# Altium schematic sheet top_media_conglomerate_adult_v01.2.SchDoc
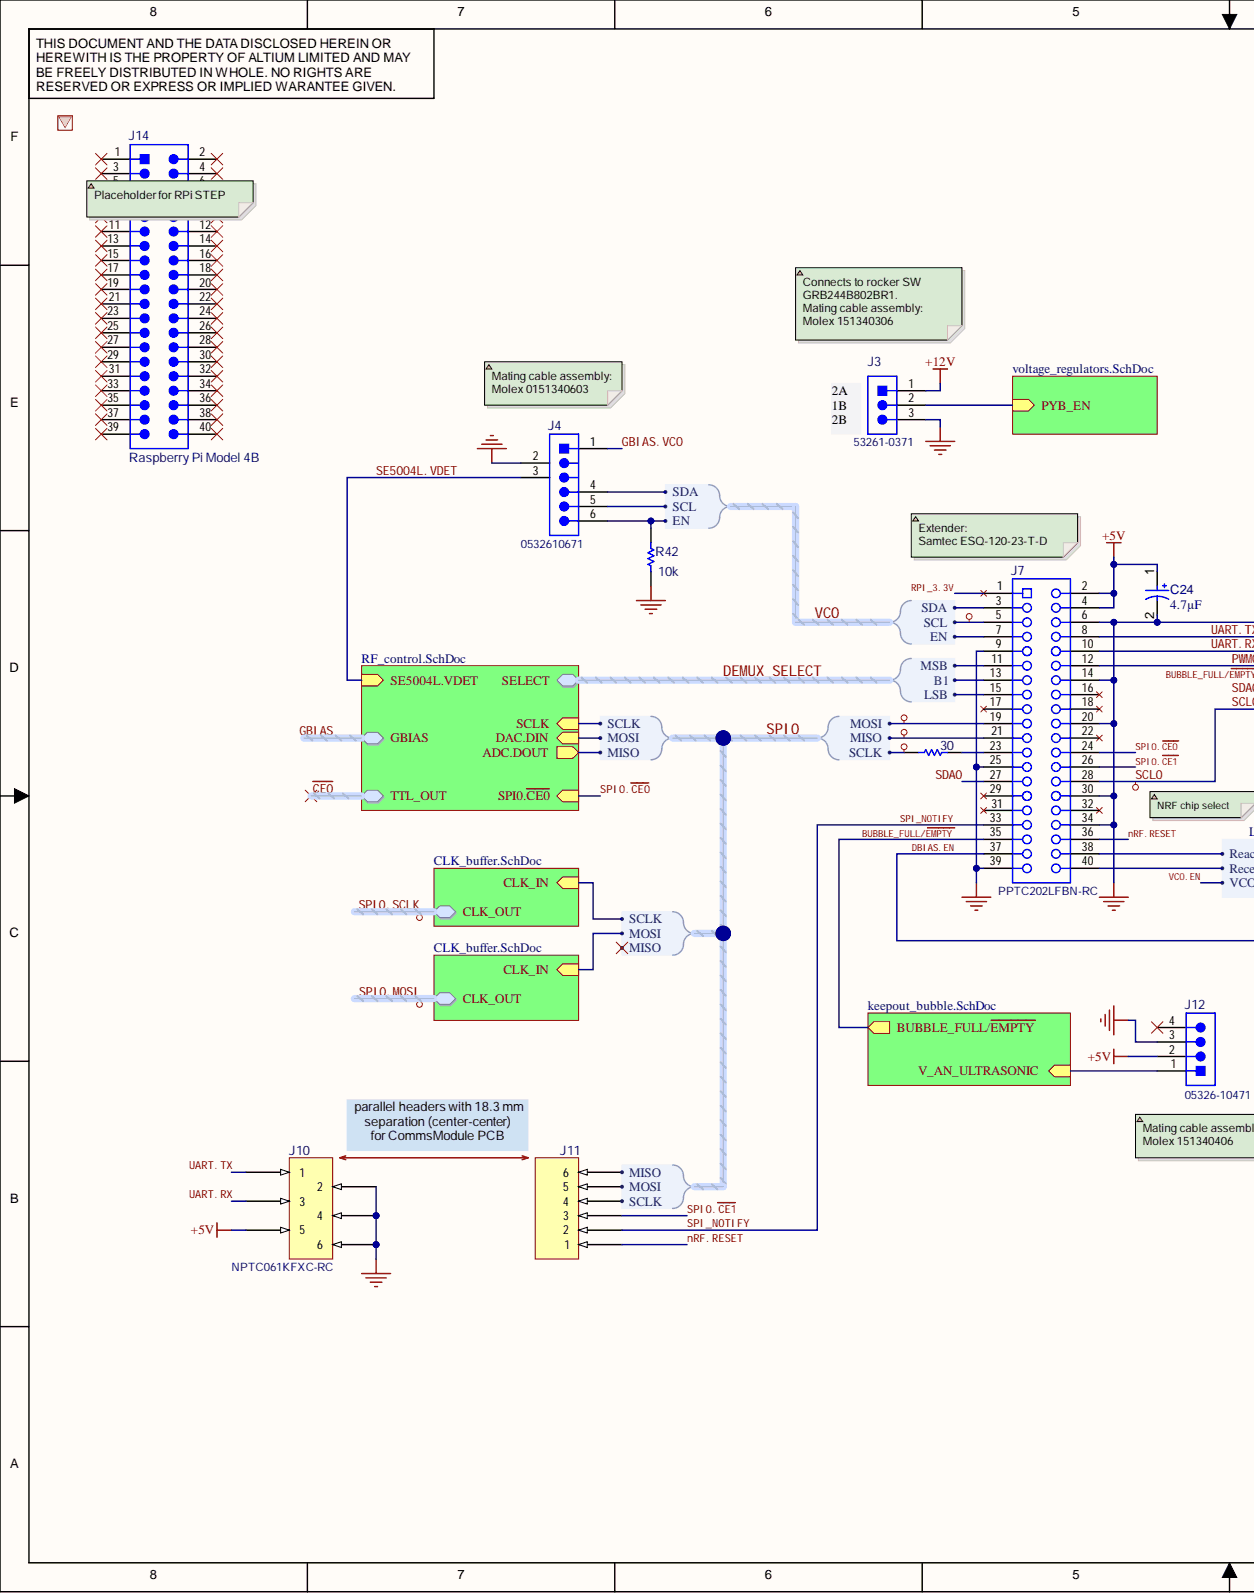
[diagram p0: top_media_conglomerate_adult_v01.2.SchDoc, left]
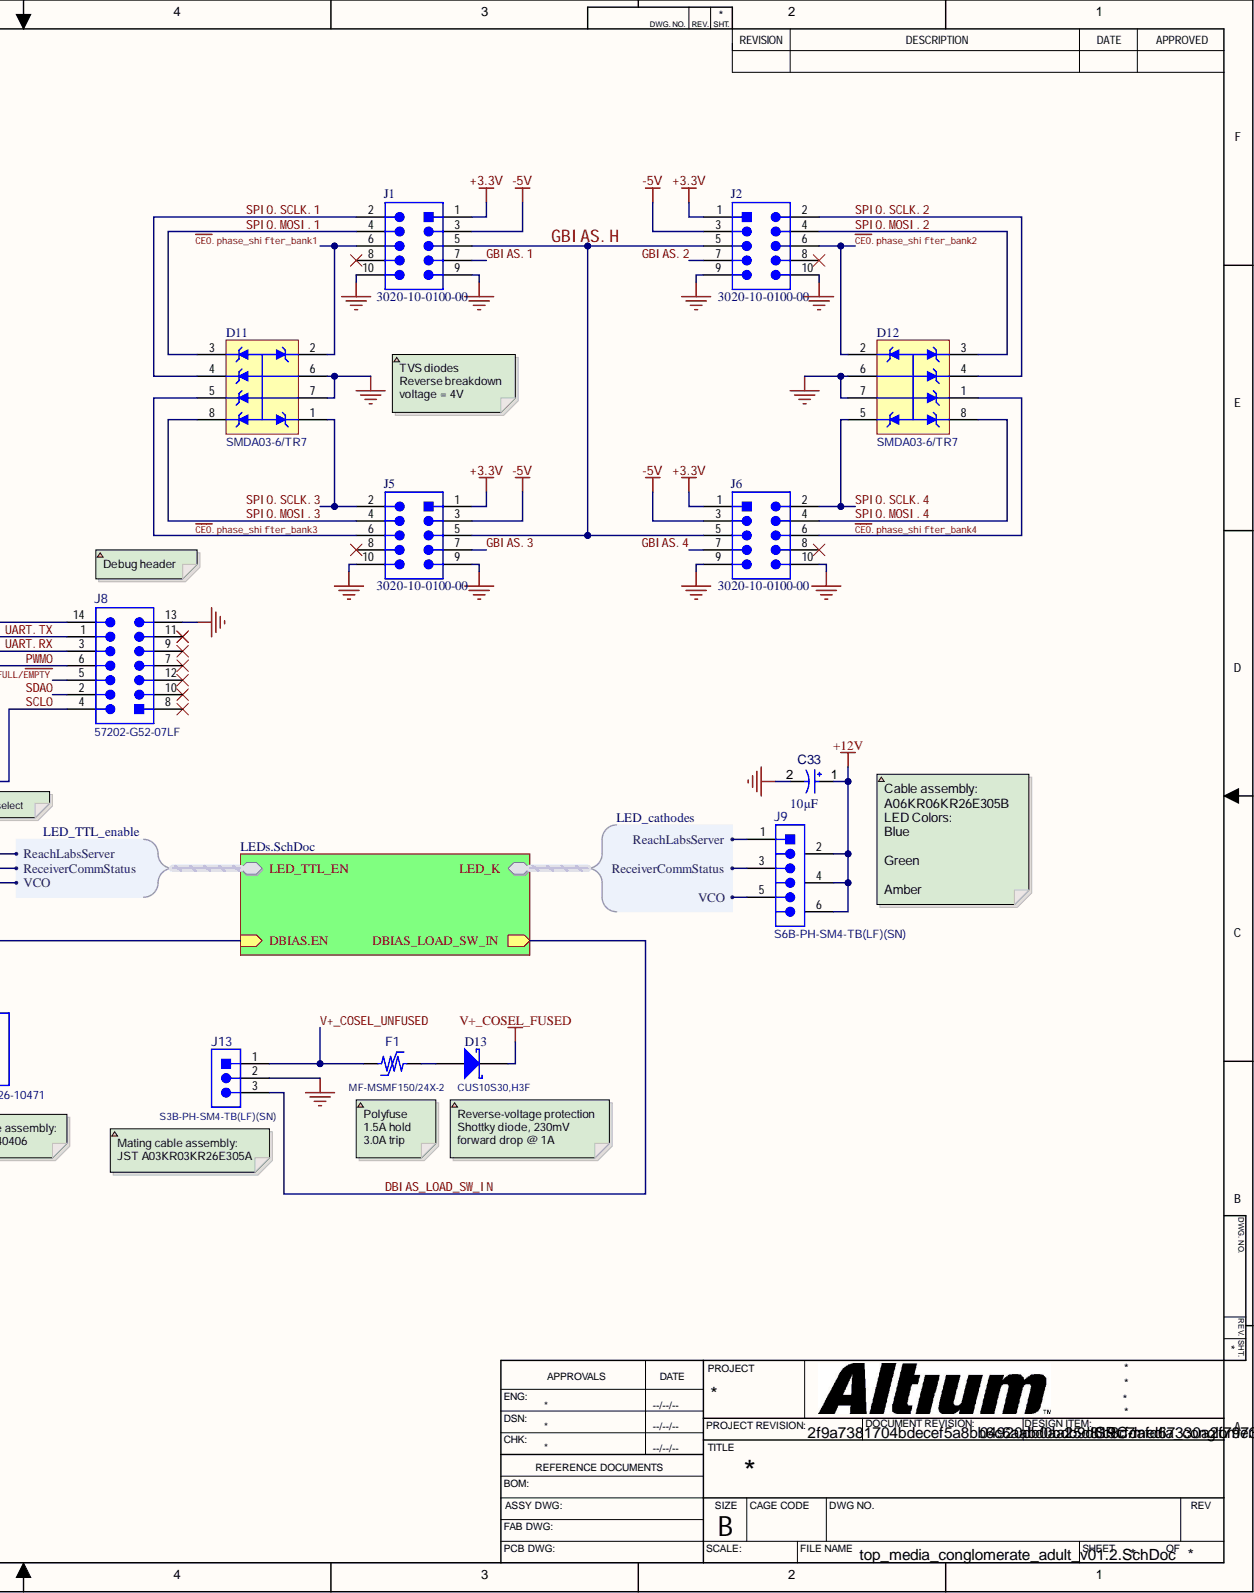
[diagram p1: top_media_conglomerate_adult_v01.2.SchDoc, right]

## Parts
C24  value 4.7µF  [AVX-TAJ-A-2_N]  (hidden comment: TAJA475K016RNJ)
C33  value 10µF  [CAPMP3529X21-T_V]  (hidden comment: TAJB106M016RNJ)
D11  SMDA03-6/TR7  [SOIC-8_500X400MM_127PITCH_N]
D12  SMDA03-6/TR7  [SOIC-8_500X400MM_127PITCH_N]
D13  CUS10S30,H3F  [DIOM1813X11N]
F1  MF-MSMF150/24X-2  [BOUR-MF-MSMF110-24X-2_V]
J1  3020-10-0100-00  [3020-10-0100-00-Footprint-1]
J2  3020-10-0100-00  [3020-10-0100-00-Footprint-1]
J3  53261-0371  [0532610371]
J4  0532610671  [05326-10671]
J5  3020-10-0100-00  [3020-10-0100-00-Footprint-1]
J6  3020-10-0100-00  [3020-10-0100-00-Footprint-1]
J7  PPTC202LFBN-RC  [PPTC202LFBN-RC]
J8  57202-G52-07LF  [57202-G52-07LF-Footprint-1]
J9  S6B-PH-SM4-TB(LF)(SN)  [JST-S6B-PH-SM4-TB(LF)(SN)_V]
J10  NPTC061KFXC-RC  [NPTC061KFXC-RC_with_comms_module]
J11  NPTC061KFXC-RC  [NPTC061KFXC-RC-Footprint-1]
J12  05326-10471  [CON-RT_4PIN_MOLEX_05326-10471]
J13  S3B-PH-SM4-TB(LF)(SN)  [JST-S3B-PH-SM4-TB-3_V]
J14  Raspberry Pi Model 4B  [Raspberry Pi Model 4B-Footprint-1]
R42  value 10k  [RESTF_0402(1005M)_SMD_N]  (hidden comment: RC0402JR-0710KL)
R43  value 30  [RESTF_0402(1005M)_SMD_L]  (hidden comment: RC0402JR-0730RL)

## Nets
+3.3V: J1.1 J2.1 J5.1 J6.1
+5V: C24.1 J7.2 J7.4 J10.5 J12.2
+12V: C33.1 J3.1 J9.2 J9.4 J9.6
-5V: J1.3 J2.3 J5.3 J6.3
GND: C24.2 C33.2 D11.6 D11.7 D12.6 D12.7 J1.9 J1.10 J2.9 J2.10 J3.3 J4.2 J5.9 J5.10 J6.9 J6.10 J7.6 J7.9 J7.14 J7.20 J7.25 J7.30 J7.34 J7.39 J8.13 J8.14 J10.2 J10.4 J10.6 J12.3 J13.2 R42.2
V+_COSEL_FUSED: D13.1
~{DBIAS_LOAD_SW_IN}: J13.3
BUBBLE_FULL/~{EMPTY}: J7.35 J8.5
~{CE0}.phase_shifter_bank1: D11.2 J1.6
~{CE0}.phase_shifter_bank2: D12.2 J2.6
~{CE0}.phase_shifter_bank3: D11.5 J5.6
~{CE0}.phase_shifter_bank4: D12.1 J6.6
DBIAS.EN: J7.37
DEMUX_SELECT.B1: J7.13
DEMUX_SELECT.LSB: J7.15
DEMUX_SELECT.MSB: J7.11
GBIAS.1: J1.7
GBIAS.2: J2.7
GBIAS.3: J5.7
GBIAS.4: J6.7
GBIAS.H: J1.5 J2.5 J5.5 J6.5
GBIAS.VCO: J4.1
nRF.RESET: J7.36 J11.1
PWM0: J7.12 J8.6
RPI_3.3V: J7.1
SCL0: J7.28 J8.4
SDA0: J7.27 J8.2
SE5004L.VDET: J4.3
SPI0.~{CE0}: J7.24
SPI0.~{CE1}: J7.26 J11.3
SPI0.MISO: J7.21 J11.6
SPI0.MOSI: J7.19 J11.5
SPI0.MOSI.1: D11.3 J1.4
SPI0.MOSI.2: D12.3 J2.4
SPI0.MOSI.3: D11.8 J5.4
SPI0.MOSI.4: D12.8 J6.4
SPI0.SCLK: J11.4 R43.1
SPI0.SCLK.1: D11.4 J1.2
SPI0.SCLK.2: D12.4 J2.2
SPI0.SCLK.3: D11.1 J5.2
SPI0.SCLK.4: D12.5 J6.2
SPI_NOTIFY: J7.33 J11.2
UART.RX: J7.10 J8.3 J10.3
UART.TX: J7.8 J8.1 J10.1
V+_COSEL_UNFUSED: F1.1 J13.1
VCO.EN: J4.6 J7.7 R42.1
VCO.SCL: J4.5 J7.5
VCO.SDA: J4.4 J7.3
NetD13_2: D13.2 F1.2
NetJ1_8: J1.8
NetJ2_8: J2.8
NetJ3_2: J3.2
NetJ5_8: J5.8
NetJ6_8: J6.8
NetJ7_16: J7.16
NetJ7_17: J7.17
NetJ7_18: J7.18
NetJ7_22: J7.22
NetJ7_23: J7.23 R43.2
NetJ7_29: J7.29
NetJ7_31: J7.31
NetJ7_32: J7.32
NetJ7_38: J7.38
NetJ7_40: J7.40
NetJ8_7: J8.7
NetJ8_8: J8.8
NetJ8_9: J8.9
NetJ8_10: J8.10
NetJ8_11: J8.11
NetJ8_12: J8.12
NetJ9_1: J9.1
NetJ9_3: J9.3
NetJ9_5: J9.5
NetJ12_1: J12.1
NetJ12_4: J12.4
NetJ14_1: J14.1
NetJ14_2: J14.2
NetJ14_3: J14.3
NetJ14_4: J14.4
NetJ14_5: J14.5
NetJ14_6: J14.6
NetJ14_7: J14.7
NetJ14_8: J14.8
NetJ14_9: J14.9
NetJ14_10: J14.10
NetJ14_11: J14.11
NetJ14_12: J14.12
NetJ14_13: J14.13
NetJ14_14: J14.14
NetJ14_15: J14.15
NetJ14_16: J14.16
NetJ14_17: J14.17
NetJ14_18: J14.18
NetJ14_19: J14.19
NetJ14_20: J14.20
NetJ14_21: J14.21
NetJ14_22: J14.22
NetJ14_23: J14.23
NetJ14_24: J14.24
NetJ14_25: J14.25
NetJ14_26: J14.26
NetJ14_27: J14.27
NetJ14_28: J14.28
NetJ14_29: J14.29
NetJ14_30: J14.30
NetJ14_31: J14.31
NetJ14_32: J14.32
NetJ14_33: J14.33
NetJ14_34: J14.34
NetJ14_35: J14.35
NetJ14_36: J14.36
NetJ14_37: J14.37
NetJ14_38: J14.38
NetJ14_39: J14.39
NetJ14_40: J14.40
~{CE0}.6:
~{CE0}.7:
~{CE0}.ADC:
~{CE0}.DAC:

## Library data of the parts
C24 = CMP-001-00042-10: Standard Tantalum, 4.7 uF, +/- 10%, 16 V, -55 to 125 degC, 1206 (3216 Metric), RoHS, Tape and Reel; Manufacturer: AVX; ESR: 4Ohm; Voltage Rating: 16V; Capacitor Type: Tantalum; Case/Package: 1206; Component Kind: Standard; Datasheet URL: http://datasheets.avx.com/TAJ.pdf; Datasheet Version: Feb-16; Library Name: Altium Concord Pro on A365; Manufacturer URL: http://www.avx.com/; Max Operating Temperature: 125°C; Min Operating Temperature: -55°C; Mounting Technology: SM; Package Description: 2-Pin Surface Mount Device, Body 3.2 x 1.65 mm; Package Quantity: 2000; Package Reference: TAJ-A-2; Packaging: TapeandReel; Pins: 2; Resistance: 4Ohm; RoHS Compliant: True; Tolerance: 10%
C33 = CMP-001-00050-8: Tantalum Capacitor, 10 uF, +/- 20%, 16 V, -55 to 125 degC, 1411 (3528 Metric), RoHS, Tape and Reel; Manufacturer: AVX Corporation; Voltage Rating: 16V; Capacitor Type: Tantalum; Case/Package: 1210; Component Kind: Standard; Datasheet URL: http://datasheets.avx.com/TAJ.pdf; Datasheet Version: 42736; Library Name: Altium Concord Pro on A365; Manufacturer URL: http://www.avx.com/; Max Operating Temperature: 125°C; Min Operating Temperature: -55°C; Mounting Technology: SM; MPN: TAJB106M016RNJ; Package Description: 2-Pin Surface Mount Device, Body 3.5 x 2.85 mm; Package Quantity: 1; Package Reference: 3528-21; Packaging: TapeandReel; Pins: 2; RoHS Compliant: True; Tolerance: 20%
D11 D12 = CMP-005-0002-3: TVS DIODE 3.3V 7V 8SO; Direction: Unidirectional; Clamping Voltage: 7V; Component Kind: Standard; Library Name: Altium Concord Pro on A365; Max Operating Temperature: 150°C; Min Operating Temperature: -55°C; Mount: Surface Mount; MPN: SMDA03-6/TR7; Number of Pins: 8; Radiation Hardening: No; Working Voltage: 3.3V
D13 = CMP-004-00032-5: DIODE SCHOTTKY 30V 1A USC; Element Configuration: Single; Peak Non-Repetitive Surge Current: 5A; Peak Reverse Current: 500uA; Average Rectified Current: 1A; Case/Package: SOD; Component Kind: Standard; Contact Plating: Tin,Silver; Library Name: Altium Concord Pro on A365; Max Operating Temperature: 125°C; Max Reverse Voltage (DC): 30V; Min Operating Temperature: -55°C; Mount: Surface Mount; Number of Pins: 2; Packaging: Cut Tape
F1 = CMP-005-00013-1: PTC Resettable Fuse 1.5A(hold) 3A(trip) 24V 20A 1W 1.5s 0.03Ohm SMD Solder Pad 1812 T/R; Approvals: UL,CSA,TUV; Case Code (Imperial): 1812; Case Code (Metric): 4532; Case/Package: 1812; Component Kind: Standard; Contact Plating: Gold; Current Rating: 1.5A; Height: 1.6mm; Hold Current: 1.5A; Length: 4.83mm; Library Name: Altium Concord Pro on A365; Max Current Rating: 20A; Max Operating Temperature: 85°C; Min Operating Temperature: -40°C; Mount: Surface Mount; Mounting Technology: SM; Number of Terminals: 2; Packaging: Tape and Reel; Power Dissipation: 1W; Radiation Hardening: No; REACH SVHC: No SVHC; RoHS Compliant: True; Terminal Material: Gold; Time to Trip: 1.5s; Trip Current: 3A; Voltage Rating: 24V; Voltage Rating (DC): 24V; Width: 3.41mm
J1 J2 J5 J6 = CMP-002-00103-3: CONN HEADER VERT 10POS 2.54MM; Connector Type: Male Pin; Number of Positions: 10; Pitch: 0.1inch; Component Kind: Standard; Contact Plating: Gold; Library Name: Altium Concord Pro on A365; Mount: Through Hole; MPN: 3020-10-0100-00; Number of Rows: 2; Package Quantity: 1560; Packaging: Tray; Row Spacing: 0.1inch; Termination: Solder
J3 = CMP-002-00085-2: CONN HEADER SMD R/A 3POS 1.25MM; Connector Type: Blade Pin; Contact Resistance: 20mR; Gender: Male; Number of Contacts: 3; System: Connector; Color: Natural; Component Kind: Standard; Contact Material: Bronze; Contact Plating: Tin; Depth: 5.2mm; Flammability Rating: UL94 V-0; Housing Color: Natural; Housing Material: Nylon; Length: 8.9mm; Library Name: Altium Concord Pro on A365; Max Operating Temperature: 85°C; Min Operating Temperature: -40°C; Mount: Surface Mount; Number of Positions: 3; Number of Rows: 1; Orientation: Right Angle; Packaging: Tape and Reel; Pins: 3; Pitch: 1.25mm; Termination: Solder
J4 = CMP-002-00086-1: Conn Shrouded Header HDR 6 POS 1.25mm Solder RA SMD PicoBlade™ Embossed T/R; Connector Type: Blade Pin; Contact Resistance: 20mR; Gender: Male; Number of Contacts: 6; System: Connector; Case/Package: 1000; Color: Natural; Component Kind: Standard; Contact Material: Bronze; Contact Plating: Tin; Depth: 5.2mm; Flammability Rating: UL94 V-0; Housing Color: Natural; Housing Material: Nylon; Length: 12.65mm; Library Name: Altium Concord Pro on A365; Max Operating Temperature: 85°C; Min Operating Temperature: -40°C; Mount: Surface Mount; Number of Positions: 6; Number of Rows: 1; Orientation: Right Angle; Packaging: Tape and Reel; Pitch: 1.25mm; Termination: Solder
J7 = CMP-009-00203-5: CONN HDR 40POS 0.1 TIN PCB; Connector Type: Header,Socket; Number of Positions: 40; Pitch: 0.1inch; System: Connector; Color: Black; Component Kind: Standard; Contact Material: Bronze; Contact Plating: Tin; Height: 8.5mm; Housing Material: Plastic; Lead Free: Lead Free; Length: 51.3mm; Library Name: Altium Concord Pro on A365; Mount: Through Hole; Number of Rows: 2; Package Quantity: 1000; Packaging: Bulk; Row Spacing: 0.1inch; Termination: Solder; Width: 5mm
J8 = CMP-002-00104-1: CONN HEADER 14POS 2MM STR DL SMD; Connector Type: Male Pin; Contact Resistance: 25mR; Current Rating: 2A; Gender: Male; Insulation Resistance: 1GR; Max Voltage Rating (AC): 650V; Number of Contacts: 14; Row Spacing: 0.079inch; System: Test; Color: Black; Component Kind: Standard; Contact Material: Bronze,Copper Alloy; Contact Mating Length: 0.157inch; Contact Plating: Gold,GXT; Depth: 4mm; Housing Color: Black; Housing Material: Thermoplastic; Lead Free: Lead Free; Length: 14mm; Library Name: Altium Concord Pro on A365; Max Operating Temperature: 125°C; Min Operating Temperature: -55°C; Mount: Surface Mount; MPN: 57202-G52-07LF; Number of Positions: 14; Number of Rows: 2; Orientation: Straight; Package Quantity: 200; Packaging: Bulk; Pitch: 2mm; Radiation Hardening: No; REACH SVHC: No SVHC; Termination: Solder; Voltage Rating: 650V; Width: 4mm
J9 = CMP-002-00099-1: CONN HEADER SMD R/A 6POS 2MM; Connector Type: Header,Male Pin; Contact Resistance: 20mR; Current Rating: 2A; Gender: Male; Insulation Resistance: 1GR; Max Voltage Rating (AC): 100V; Number of Contacts: 6; System: Connector; Color: White; Component Kind: Standard; Contact Material: Copper Alloy,Bronze,Tin,Brass; Contact Mating Length: 0.13inch; Contact Plating: Tin,Copper; Contact Shape: Square; Depth: 7.6mm; Fastening Type: Detent; Flammability Rating: UL94 V-0; Height: 9.4mm; Housing Color: Beige; Housing Material: Polyamide; Insulation Color: Natural; Insulation Material: Nylon; Lead Free: Lead Free; Lead Pitch: 2mm; Length: 15.9mm; Library Name: Altium Concord Pro on A365; Max Current Rating: 2A; Max Operating Temperature: 85°C; Max Voltage Rating (DC): 100V; Min Operating Temperature: -25°C; Mount: Surface Mount; MPN: S6B-PH-SM4-TB(LF)(SN); Number of Poles: 6; Number of Positions: 6; Number of Rows: 1; Orientation: Right Angle; Packaging: Tape and Reel; Pitch: 2mm; REACH SVHC: No SVHC; Termination: Solder; Voltage Rating: 100V; Voltage Rating (AC): 100V; Width: 5mm; Working Voltage: 100V
J10 J11 = CMP-002-00087-2: CONN HDR 6POS 0.1 TIN SMD; Connector Type: Header,Socket; Number of Positions: 6; Pitch: 0.1inch; System: Connector; Color: Black; Component Kind: Standard; Contact Material: Bronze; Contact Plating: Tin; Height: 7.1mm; Housing Color: Black; Housing Material: Nylon; Lead Free: Lead Free; Length: 15.2mm; Library Name: Altium Concord Pro on A365; Mount: Surface Mount; Number of Rows: 1; Package Quantity: 1000; Packaging: Bulk; Termination: Solder; Width: 2.5mm
J12 = CMP-002-00071-2: 1.25mm (.049") Pitch PicoBlade Header, Surface Mount, Right Angle, Lead-free, 4 Circuits; Manufacturer: Molex; Manufacturer Part Number: 53261-0471; Contact Resistance: 20mR; Current Rating: 1A; Gender: Male; Glow Wire Compliant: Non-compliant; Insulation Resistance: 100MR; Number of Circuits: 4; System: Connector; Voltage Rating: 125V; Case/Package: 1000; Color: Beige; Component Kind: Standard; ComponentLink1Description: https://datasheet.ciiva.com/5153/0532610471-pcb-headers-170942-5153858.pdf?src-supplier=Mouser; ComponentLink1URL: https://datasheet.ciiva.com/5153/0532610471-pcb-headers-170942-5153858.pdf?src-supplier=Mouser; ComponentLink2Description: https://datasheet.ciiva.com/3932/272700532610471-pcb-headers-3932851.pdf?src-supplier=Arrow; ComponentLink2URL: https://datasheet.ciiva.com/3932/272700532610471-pcb-headers-3932851.pdf?src-supplier=Arrow; ComponentLink3Description: https://datasheet.ciiva.com/11750/0900766b8078fc7e-11750771.pdf?src-supplier=RSComponents; ComponentLink3URL: https://datasheet.ciiva.com/11750/0900766b8078fc7e-11750771.pdf?src-supplier=RSComponents; ComponentLink4Description: https://datasheet.ciiva.com/30219/60210532610471-pcb-headers-30219733.pdf?src-supplier=Onlinecomponents.com; ComponentLink4URL: https://datasheet.ciiva.com/30219/60210532610471-pcb-headers-30219733.pdf?src-supplier=Onlinecomponents.com; ComponentLink5Description: https://datasheet.ciiva.com/7844/1867384-7844038.pdf?src-supplier=Element14; ComponentLink5URL: https://datasheet.ciiva.com/7844/1867384-7844038.pdf?src-supplier=Element14; ComponentLink6Description: https://datasheet.ciiva.com/18448/70091119-18448940.pdf?src-supplier=Allied+Electronics; ComponentLink6URL: https://datasheet.ciiva.com/18448/70091119-18448940.pdf?src-supplier=Allied+Electronics; ComponentLink7Description: http://datasheet.octopart.com/532610471-Molex-datasheet-8343080.pdf?src-supplier=TME; ComponentLink7URL: http://datasheet.octopart.com/532610471-Molex-datasheet-8343080.pdf?src-supplier=TME; ComponentLink8Description: http://datasheet.octopart.com/53261-0471-Molex-datasheet-8522546.pdf?src-supplier=Factory+Futures; ComponentLink8URL: http://datasheet.octopart.com/53261-0471-Molex-datasheet-8522546.pdf?src-supplier=Factory+Futures; ComponentLink9Description: http://datasheet.octopart.com/53261-0471-Molex-datasheet-12521482.pdf?src-supplier=Factory+Futures; ComponentLink9URL: http://datasheet.octopart.com/53261-0471-Molex-datasheet-12521482.pdf?src-supplier=Factory+Futures; ComponentLink10Description: http://datasheet.octopart.com/53261-0471-Molex-datasheet-13786152.pdf?src-supplier=Sager+Electronics; ComponentLink10URL: http://datasheet.octopart.com/53261-0471-Molex-datasheet-13786152.pdf?src-supplier=Sager+Electronics; ComponentLink11Description: http://sigma.octopart.com/16413766/technical_drawing/Molex-53261-0471.pdf?src-supplier=Molex; ComponentLink11URL: http://sigma.octopart.com/16413766/technical_drawing/Molex-53261-0471.pdf?src-supplier=Molex; ComponentLink12Description: http://datasheet.octopart.com/53261-0471-Molex-datasheet-14711293.pdf?src-supplier=Farnell; ComponentLink12URL: http://datasheet.octopart.com/53261-0471-Molex-datasheet-14711293.pdf?src-supplier=Farnell; ComponentLink13Description: http://datasheet.octopart.com/53261-0471-Molex-datasheet-66578537.pdf?src-supplier=Element14; ComponentLink13URL: http://datasheet.octopart.com/53261-0471-Molex-datasheet-66578537.pdf?src-supplier=Element14; ComponentLink14Description: http://datasheet.octopart.com/53261-0471-Molex-datasheet-101583401.pdf?src-supplier=Farnell; ComponentLink14URL: http://datasheet.octopart.com/53261-0471-Molex-datasheet-101583401.pdf?src-supplier=Farnell; ComponentLink15Description: http://datasheet.octopart.com/53261-0471-Molex-datasheet-143843.pdf?src-supplier=Digi-Key; ComponentLink15URL: http://datasheet.octopart.com/53261-0471-Molex-datasheet-143843.pdf?src-supplier=Digi-Key; ComponentLink16Description: http://datasheet.octopart.com/532610471-Molex-datasheet-520094.pdf?src-supplier=Farnell; ComponentLink16URL: http://datasheet.octopart.com/532610471-Molex-datasheet-520094.pdf?src-supplier=Farnell; ComponentLink17Description: http://datasheet.octopart.com/53261-0471-Molex-datasheet-11023919.pdf?src-supplier=Farnell; ComponentLink17URL: http://datasheet.octopart.com/53261-0471-Molex-datasheet-11023919.pdf?src-supplier=Farnell; ComponentLink18Description: http://datasheet.octopart.com/0532610471-Molex-datasheet-11794412.pdf?src-supplier=Arrow; ComponentLink18URL: http://datasheet.octopart.com/0532610471-Molex-datasheet-11794412.pdf?src-supplier=Arrow; ComponentLink19Description: http://datasheet.octopart.com/53261-0471-Molex-datasheet-100429.pdf?src-supplier=Element14; ComponentLink19URL: http://datasheet.octopart.com/53261-0471-Molex-datasheet-100429.pdf?src-supplier=Element14; ComponentLink20Description: http://datasheet.octopart.com/53261-0471-Molex-datasheet-8331727.pdf?src-supplier=Element14; ComponentLink20URL: http://datasheet.octopart.com/53261-0471-Molex-datasheet-8331727.pdf?src-supplier=Element14; ComponentLink21Description: http://datasheet.octopart.com/53261-0471-Molex-datasheet-17741844.pdf?src-supplier=Jameco; ComponentLink21URL: http://datasheet.octopart.com/53261-0471-Molex-datasheet-17741844.pdf?src-supplier=Jameco; ComponentLink22Description: http://datasheet.octopart.com/53261-0471-Molex-datasheet-23710576.pdf?src-supplier=Electro+Sonic; ComponentLink22URL: http://datasheet.octopart.com/53261-0471-Molex-datasheet-23710576.pdf?src-supplier=Electro+Sonic; ComponentLink23Description: http://datasheet.octopart.com/53261-0471-Molex-datasheet-34442330.pdf?src-supplier=Allied+Electronics; ComponentLink23URL: http://datasheet.octopart.com/53261-0471-Molex-datasheet-34442330.pdf?src-supplier=Allied+Electronics; ComponentLink24Description: http://datasheet.octopart.com/53261-0471-Molex-datasheet-62105449.pdf?src-supplier=Electro+Sonic; ComponentLink24URL: http://datasheet.octopart.com/53261-0471-Molex-datasheet-62105449.pdf?src-supplier=Electro+Sonic; ComponentLink25Description: http://datasheet.octopart.com/53261-0471-Molex-datasheet-77521243.pdf?src-supplier=Newark; ComponentLink25URL: http://datasheet.octopart.com/53261-0471-Molex-datasheet-77521243.pdf?src-supplier=Newark; ComponentLink26Description: http://datasheet.octopart.com/53261-0471-Molex-datasheet-120153899.pdf?src-supplier=Allied+Electronics; ComponentLink26URL: http://datasheet.octopart.com/53261-0471-Molex-datasheet-120153899.pdf?src-supplier=Allied+Electronics; Contact Material: Bronze; Contact Plating: Tin; Design Item ID: 05326-10471; Flammability Rating: UL94 V-0; Housing Material: Thermoplastic; Lead Free: Lead Free; Lead Pitch: 1.25mm; Library Name: Altium Concord Pro on A365; Max Operating Temperature: 85°C; Min Operating Temperature: -40°C; Mount: Surface Mount; MPN: 05326-10471; Number of Contacts: 4; Number of Positions: 4; Number of Rows: 1; Orientation: Right Angle; Packaging: Tape and Reel; Pitch: 1.25mm; Pricing 1: 1=1.24000, 10=1.08900, 100=0.93970, 500=0.76884 (USD); Radiation Hardening: No; REACH SVHC: Unknown; Stock 1: 115; Termination: SMD/SMT; Value: 05326-10471; Voltage Rating (AC): 125V; Voltage Rating (DC): 125V; Working Voltage: 125V; Source: Upload1.SchLib
J13 = CMP-002-00100-1: JST (JAPAN SOLDERLESS TERMINALS)   S3B-PH-SM4-TB(LF)(SN)   HEADER, SMT, RIGHT ANGLE, 2MM, 3WAY; Connector Type: Male Pin,Header; Contact Resistance: 10mR; Current Rating: 2A; Gender: Male; Insulation Resistance: 1GR; Max Voltage Rating (AC): 100V; Number of Contacts: 3; System: Connector; Color: Natural,White; Component Kind: Standard; Contact Material: Copper Alloy,Tin,Bronze,Brass; Contact Mating Length: 0.13inch; Contact Plating: Tin,Copper; Contact Shape: Square; Depth: 7.6mm; Fastening Type: Detent; Flammability Rating: UL94 V-0; Height: 9.4mm; Housing Color: Beige; Housing Material: Polyamide; Insulation Color: Natural; Insulation Material: Nylon; Lead Free: Lead Free; Lead Pitch: 2mm; Length: 9.9mm; Library Name: Altium Concord Pro on A365; Material: Polyamide; Max Current Rating: 2A; Max Operating Temperature: 85°C; Max Voltage Rating (DC): 100V; Min Operating Temperature: -25°C; Mount: Surface Mount; MPN: S3B-PH-SM4-TB(LF)(SN); Number of Poles: 3; Number of Positions: 3; Number of Rows: 1; Orientation: Right Angle; Packaging: Tape and Reel; Pitch: 2mm; REACH SVHC: No SVHC; Termination: Solder; Voltage Rating: 100V; Voltage Rating (AC): 100V; Width: 5mm; Working Voltage: 100V
J14 = CMP-00028-00007-1: Component Kind: Standard; Description: Raspberry Pi Model 4B; Library Name: Altium Concord Pro on A365; MPN: Raspberry Pi Model 4B
R42 = CMP-003-0010-2: 10 kOhms ±5% 0.063W, 1/16W Chip Resistor 0402 (1005 Metric) Moisture Resistant Thick Film; Manufacturer: Yageo; Max Power Dissipation: 63mW; Power Rating: 62.5mW; Temperature Coefficient: 100ppm/°C; Voltage Rating: 50V; Case Code (Metric): 1005; Case/Package: 0402; Component Kind: Standard; ComponentLink1Description: https://datasheet.ciiva.com/2452/pyu-r-int-thick-7-2452626.pdf?src-supplier=Digi-Key; ComponentLink1URL: https://datasheet.ciiva.com/2452/pyu-r-int-thick-7-2452626.pdf?src-supplier=Digi-Key; ComponentLink2Description: https://datasheet.ciiva.com/2452/pyu-r-int-thick-7-2452627.pdf?src-supplier=Digi-Key; ComponentLink2URL: https://datasheet.ciiva.com/2452/pyu-r-int-thick-7-2452627.pdf?src-supplier=Digi-Key; ComponentLink3Description: https://datasheet.ciiva.com/2452/pyu-r-int-thick-7-2452628.pdf?src-supplier=Digi-Key; ComponentLink3URL: https://datasheet.ciiva.com/2452/pyu-r-int-thick-7-2452628.pdf?src-supplier=Digi-Key; ComponentLink4Description: https://datasheet.ciiva.com/12669/pyu-rc0402-51-rohs-l-6-r-12669687.pdf?src-supplier=Mouser; ComponentLink4URL: https://datasheet.ciiva.com/12669/pyu-rc0402-51-rohs-l-6-r-12669687.pdf?src-supplier=Mouser; ComponentLink5Description: https://datasheet.ciiva.com/7850/1018594-7850136.pdf?src-supplier=Element14; ComponentLink5URL: https://datasheet.ciiva.com/7850/1018594-7850136.pdf?src-supplier=Element14; ComponentLink6Description: https://datasheet.ciiva.com/7850/1018594-7850137.pdf?src-supplier=Element14; ComponentLink6URL: https://datasheet.ciiva.com/7850/1018594-7850137.pdf?src-supplier=Element14; Composition: Thick Film; Depth: 0.5mm; Design Item ID: RESTF_0402_10K_1/16W_YAGEO_RC0402JR-0710KL; Features: Moisture Resistant; Height: 0.016inch; Lead Free: Lead Free; Length: 1mm; Library Name: Altium Concord Pro on A365; Manufacturer Part Number: RC0402JR-0710KL; Max Operating Temperature: 155°C; Military Standard: Not; Min Operating Temperature: -55°C; MPN: RC0402JR-0710KL; Number of Terminations: 2; Package Quantity: 1; Packaging: Tape and Reel; Pricing 1: 1=0.10000, 10=0.01300, 100=0.00530, 1000=0.00236, 2500=0.00205, 5000=0.00169 (USD); REACH SVHC: Unknown; RoHS: Yes; Source: Resistors.SchLib; Stock 1: 27635471; Termination: SMD/SMT; Tolerance: 5%; Width: 0.02inch
R43 = CMP-003-0026-2: 30 Ohms ±5% 0.063W, 1/16W Chip Resistor 0402 (1005 Metric) Moisture Resistant Thick Film; Max Power Dissipation: 63mW; Power Rating: 62.5mW; Resistance: 30R; Temperature Coefficient: 100ppm/°C; Voltage Rating: 50V; Case Code (Imperial): 0402; Case Code (Metric): 1005; Case/Package: 0402; Component Kind: Standard; Composition: Thick Film; Contact Plating: Tin,Matte; Depth: 0.5mm; Features: Moisture Resistant; Height: 0.016inch; Lead Free: Lead Free; Length: 1mm; Library Name: Altium Concord Pro on A365; Max Operating Temperature: 155°C; Military Standard: Not; Min Operating Temperature: -55°C; Number of Pins: 2; Number of Terminations: 2; Package Quantity: 1; Packaging: Tape and Reel; Pins: 2; Radiation Hardening: No; Termination: SMD/SMT; Tolerance: 5%; Voltage Rating (DC): 50V; Width: 0.02inch
